# Altium schematic sheet PoE.SchDoc(PoE)
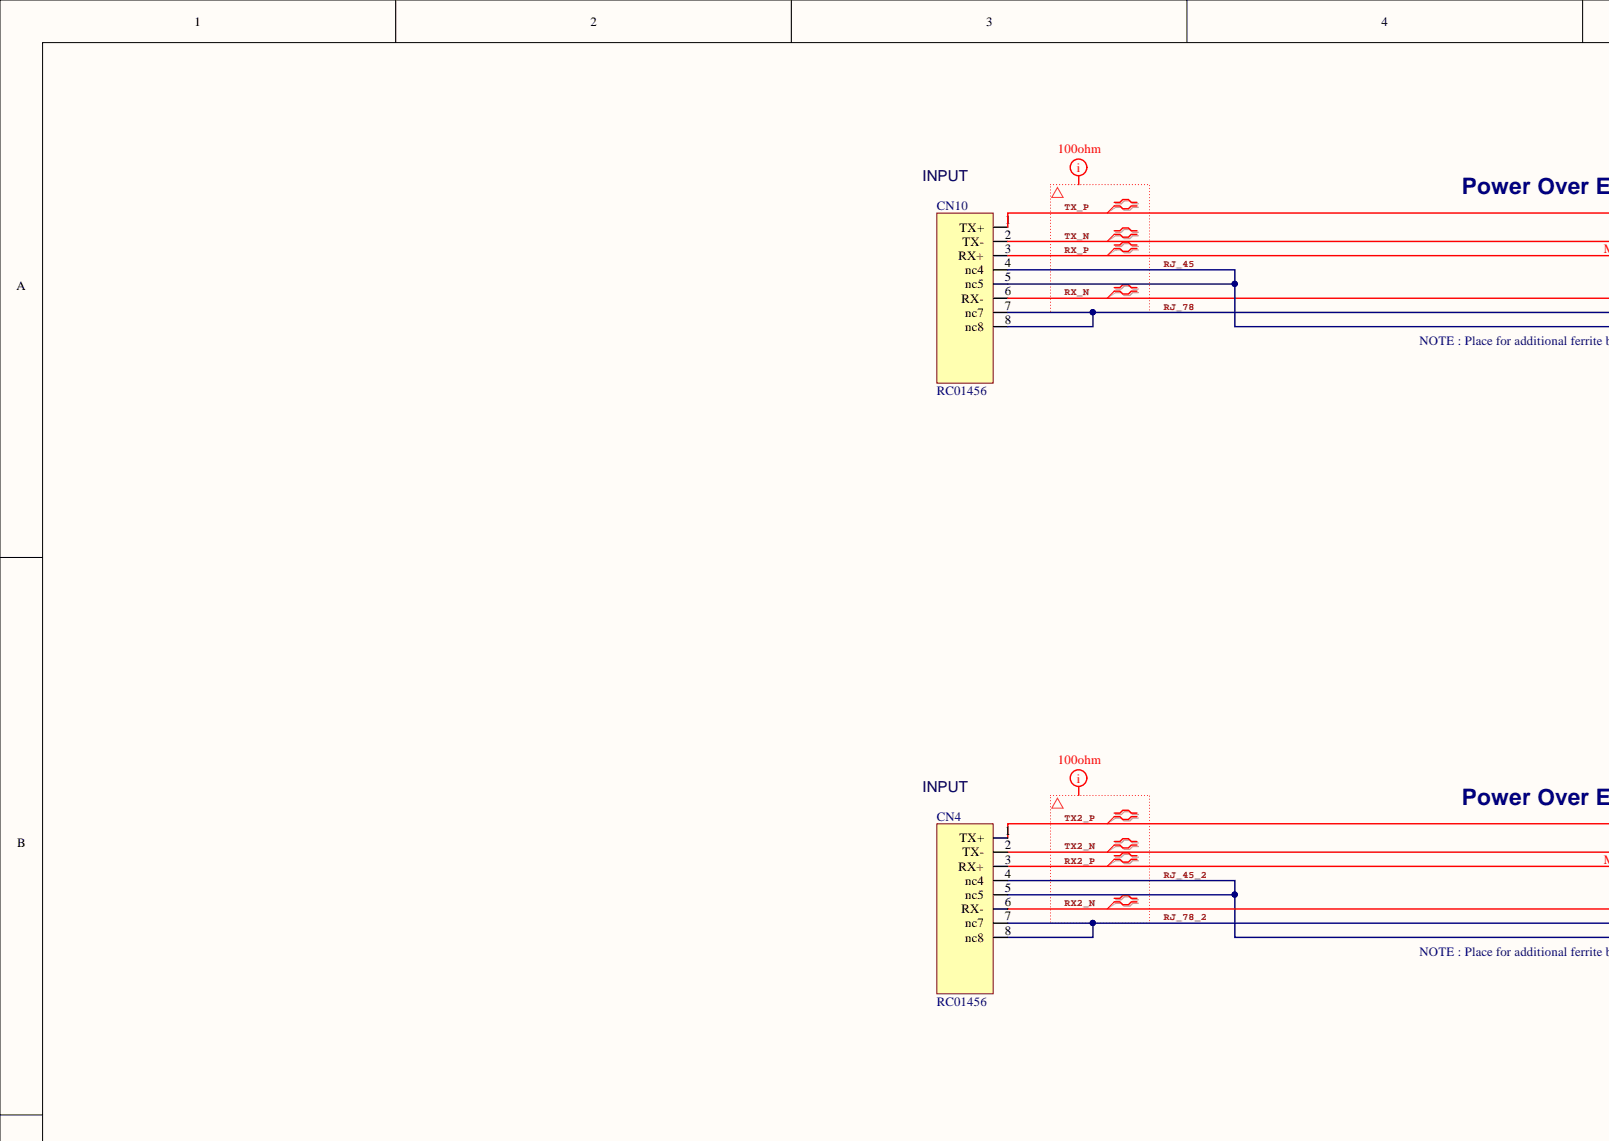
[diagram p0: PoE.SchDoc, top left]
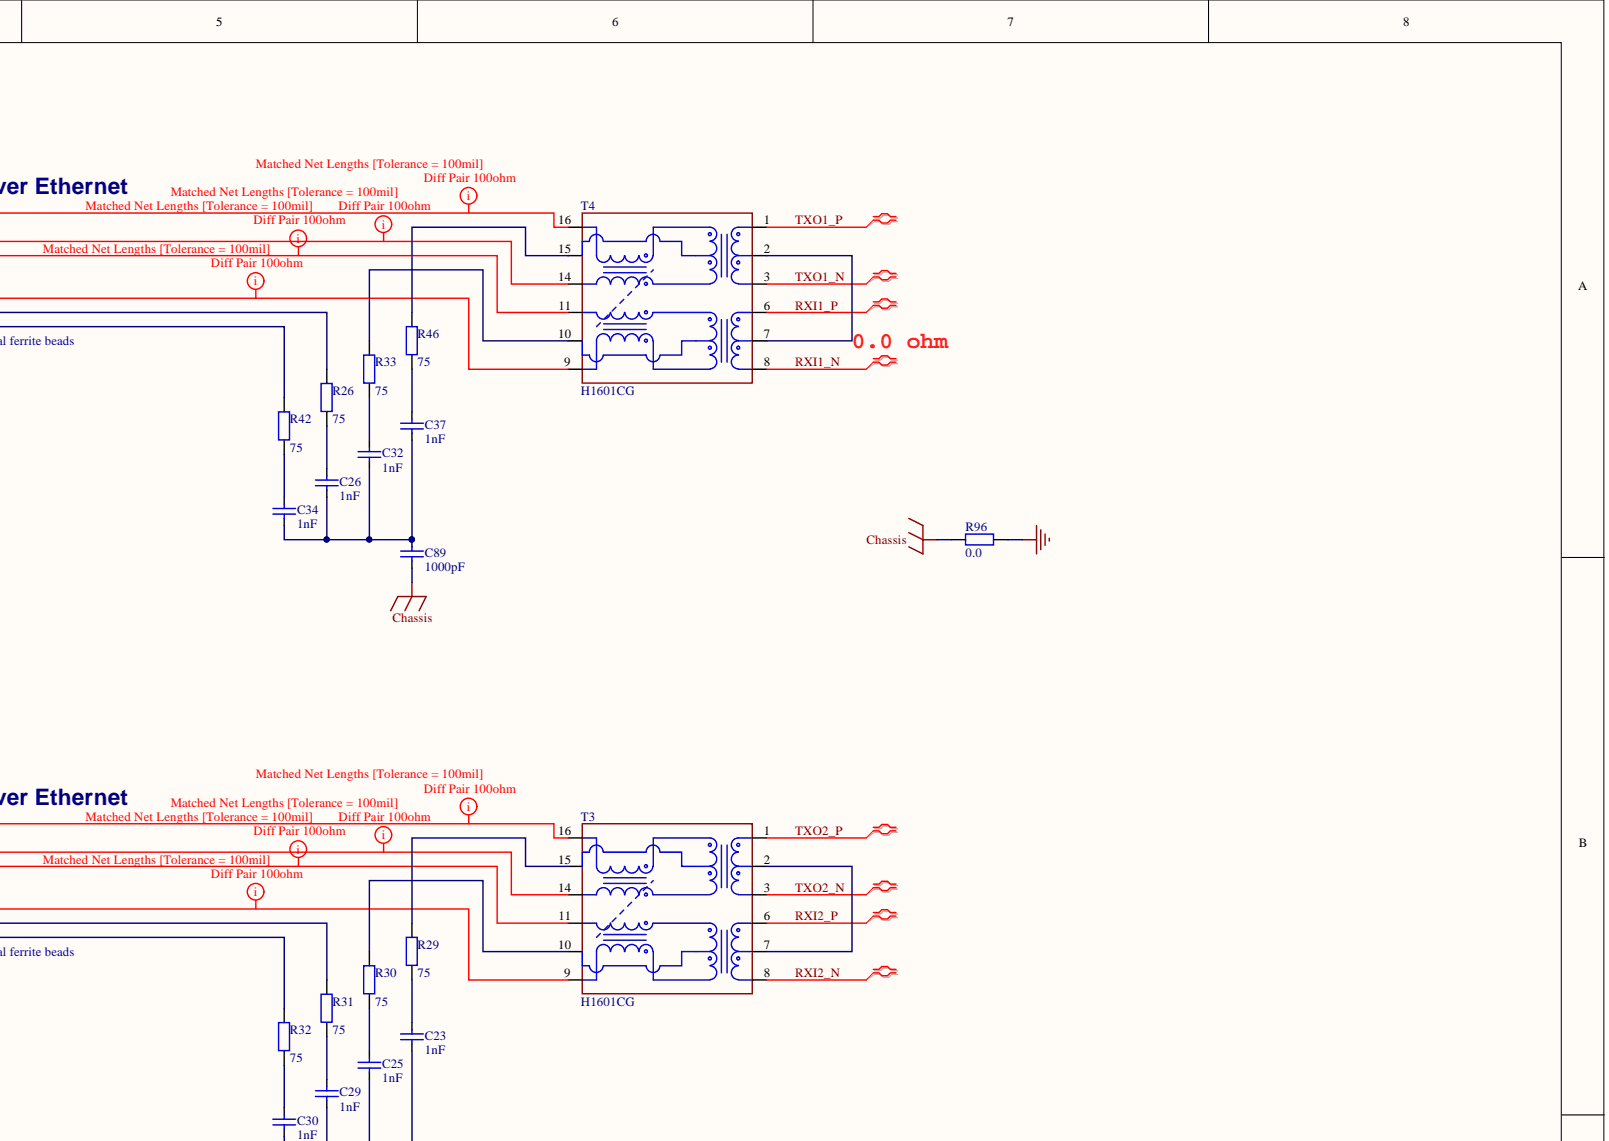
[diagram p1: PoE.SchDoc, top right]
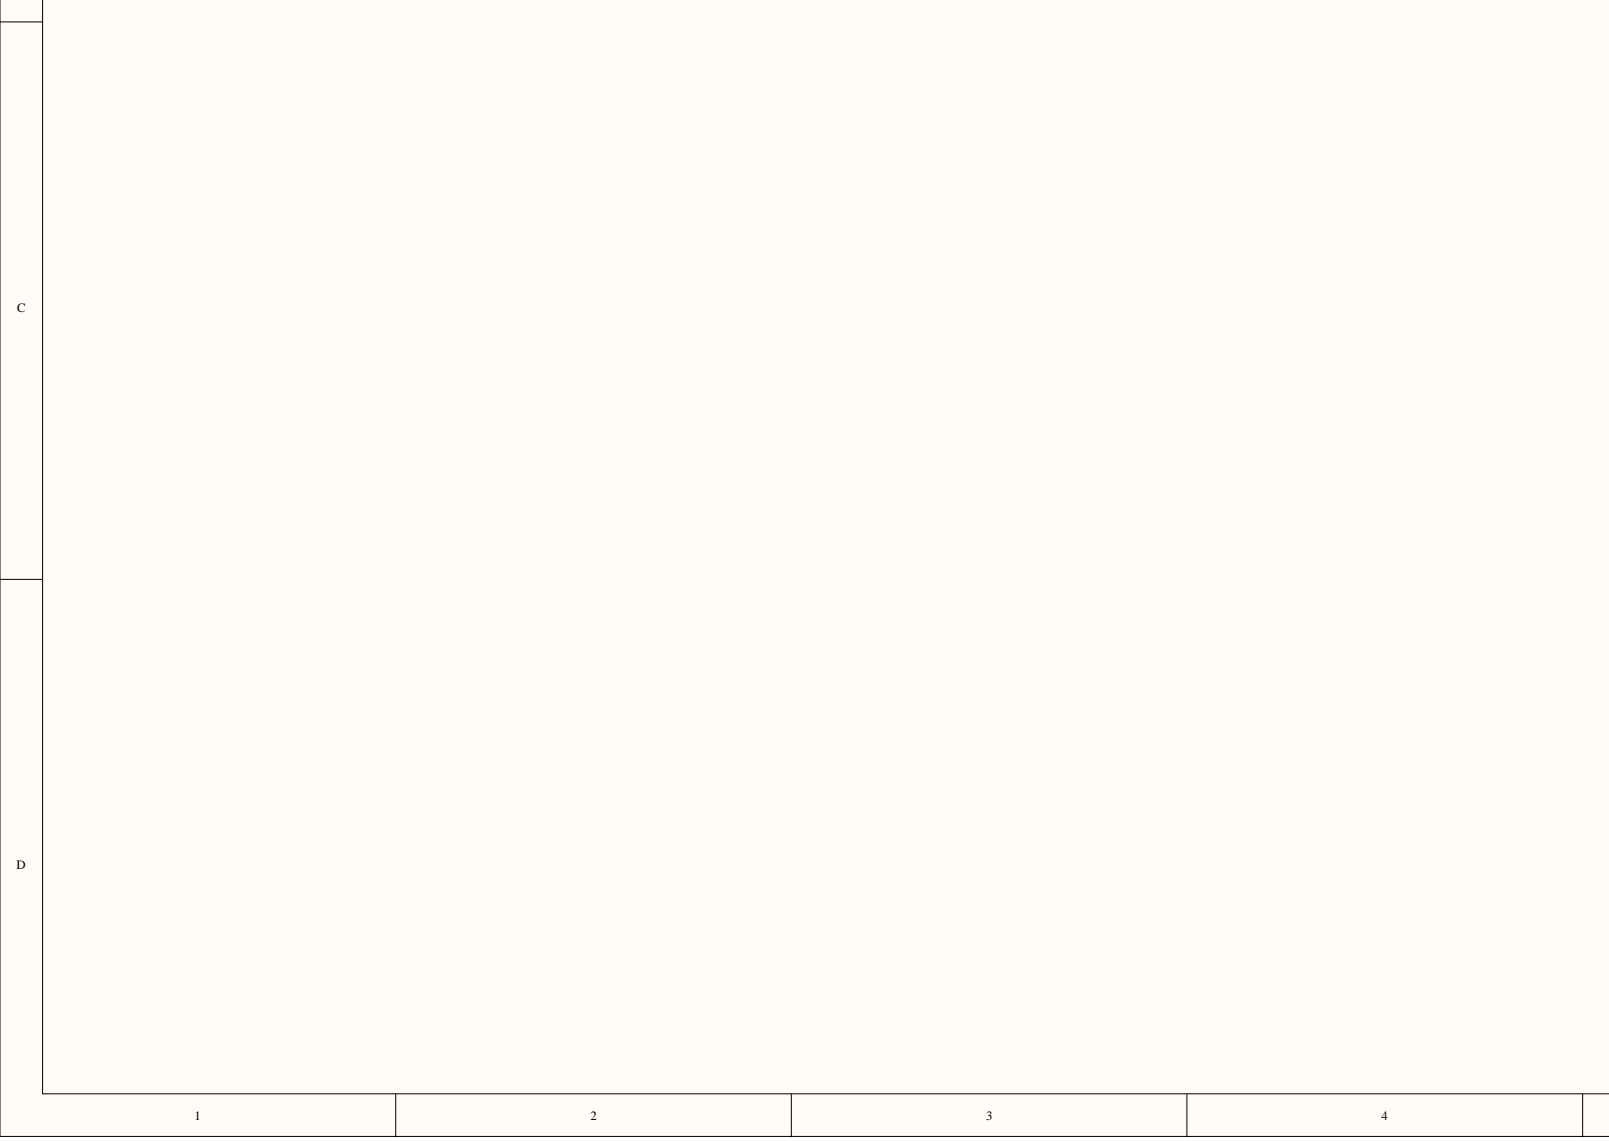
[diagram p2: PoE.SchDoc, bottom left]
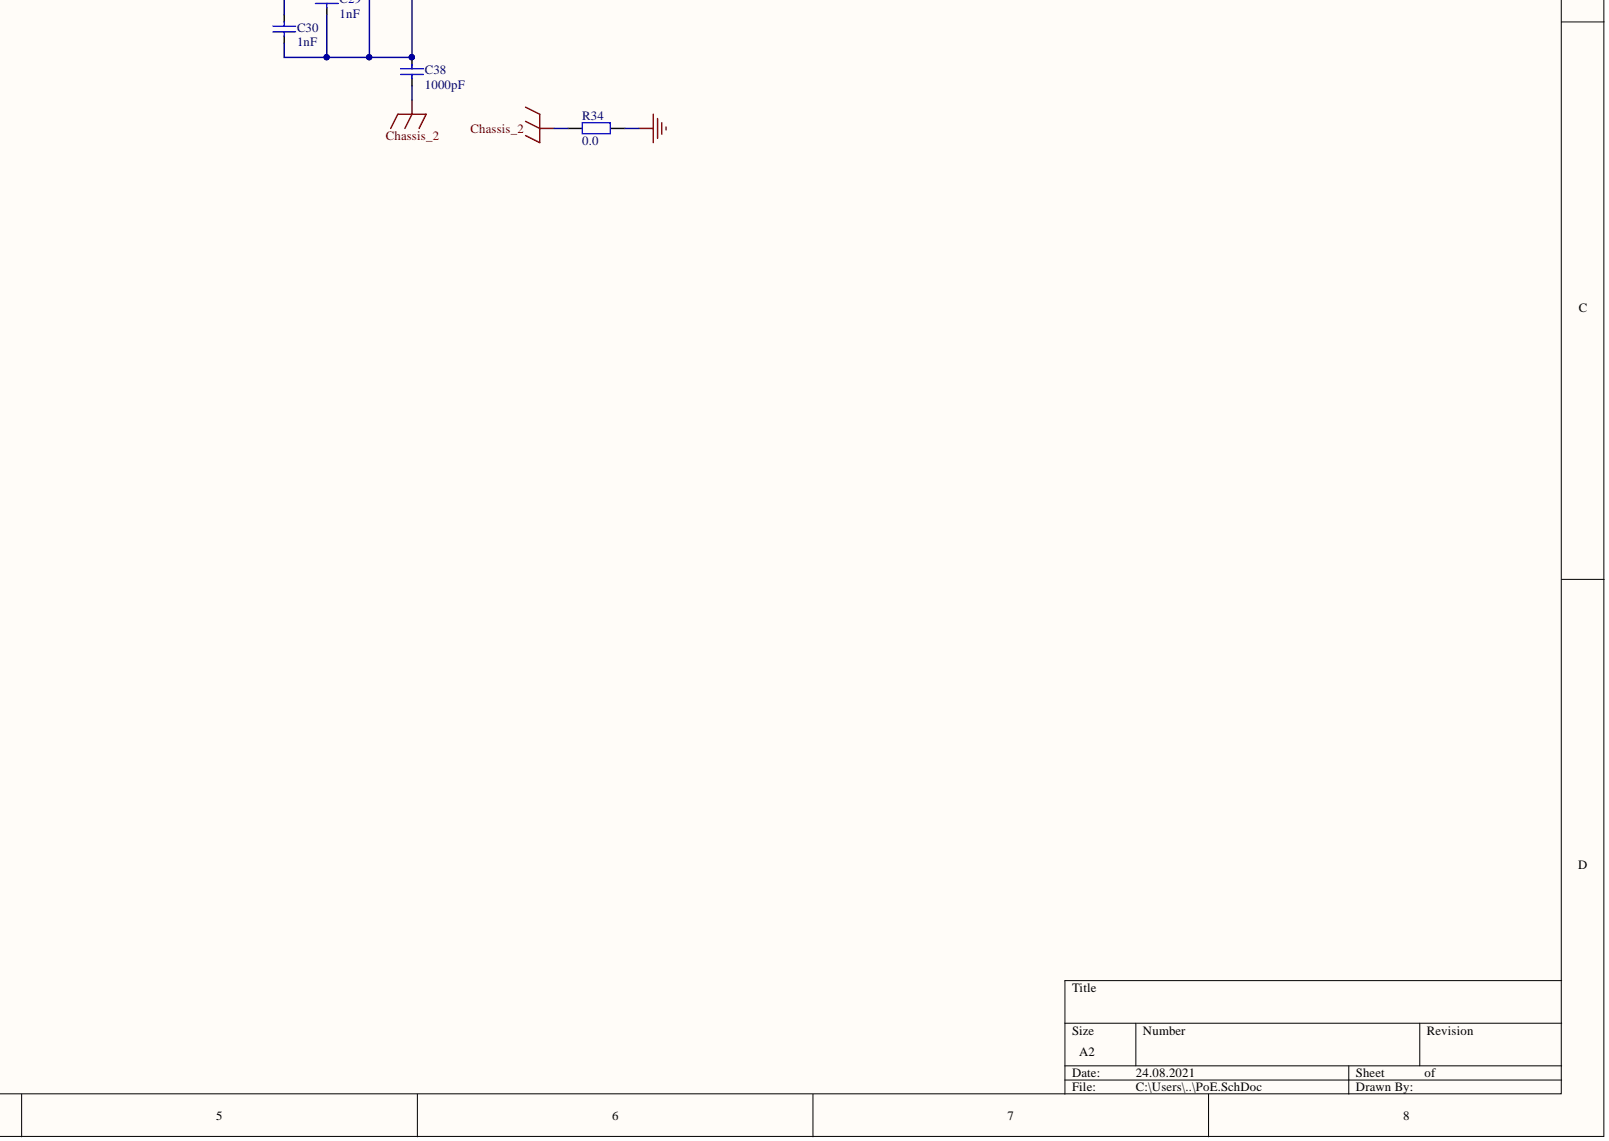
[diagram p3: PoE.SchDoc, bottom right]

## Parts
C23  value 1nF  [CAP0603R]  (hidden comment: CL10B102KC8NNNC)
C25  value 1nF  [CAP0603R]  (hidden comment: CL10B102KC8NNNC)
C26  value 1nF  [CAP0603R]  (hidden comment: CL10B102KC8NNNC)
C29  value 1nF  [CAP0603R]  (hidden comment: CL10B102KC8NNNC)
C30  value 1nF  [CAP0603R]  (hidden comment: CL10B102KC8NNNC)
C32  value 1nF  [CAP0603R]  (hidden comment: CL10B102KC8NNNC)
C34  value 1nF  [CAP0603R]  (hidden comment: CL10B102KC8NNNC)
C37  value 1nF  [CAP0603R]  (hidden comment: CL10B102KC8NNNC)
C38  value 1000pF  [CAP1206R]  (hidden comment: CC1206KKX7RDBB102)
C89  value 1000pF  [CAP1206R]  (hidden comment: CC1206KKX7RDBB102)
CN4  RC01456  [HY506-Footprint-1]
CN10  RC01456  [HY506-Footprint-1]
R26  value 75  [0603]  (hidden comment: 0603WAF750JT5E)
R29  value 75  [0603]  (hidden comment: 0603WAF750JT5E)
R30  value 75  [0603]  (hidden comment: 0603WAF750JT5E)
R31  value 75  [0603]  (hidden comment: 0603WAF750JT5E)
R32  value 75  [0603]  (hidden comment: 0603WAF750JT5E)
R33  value 75  [0603]  (hidden comment: 0603WAF750JT5E)
R34  value 0.0  [0805]  (hidden comment: CMP-022-0137-1)
R42  value 75  [0603]  (hidden comment: 0603WAF750JT5E)
R46  value 75  [0603]  (hidden comment: 0603WAF750JT5E)
R96  value 0.0  [0805]  (hidden comment: CMP-022-0137-1)
T3  H1601CG  [TF-16SM]
T4  H1601CG  [TF-16SM]

## Nets
Chassis: C89.1 R96.1
Chassis_2: C38.1 R34.1
GND: R34.2 R96.2
RJ_45: CN10.4(nc4) CN10.5(nc5) R42.2
RJ_45_2: CN4.4(nc4) CN4.5(nc5) R32.2
RJ_78: CN10.7(nc7) CN10.8(nc8) R26.2
RJ_78_2: CN4.7(nc7) CN4.8(nc8) R31.2
RX2_N: CN4.6(RX-) T3.9
RX2_P: CN4.3(RX+) T3.11
RX_N: CN10.6(RX-) T4.9
RX_P: CN10.3(RX+) T4.11
RXI1_N: T4.8
RXI1_P: T4.6
RXI2_N: T3.8
RXI2_P: T3.6
TX2_N: CN4.2(TX-) T3.14
TX2_P: CN4.1(TX+) T3.16
TX_N: CN10.2(TX-) T4.14
TX_P: CN10.1(TX+) T4.16
TXO1_N: T4.3
TXO1_P: T4.1
TXO2_N: T3.3
TXO2_P: T3.1
NetC23_1: C23.1 C25.1 C29.1 C30.1 C38.2
NetC23_2: C23.2 R29.1
NetC25_2: C25.2 R30.1
NetC26_1: C26.1 C32.1 C34.1 C37.1 C89.2
NetC26_2: C26.2 R26.1
NetC29_2: C29.2 R31.1
NetC30_2: C30.2 R32.1
NetC32_2: C32.2 R33.1
NetC34_2: C34.2 R42.1
NetC37_2: C37.2 R46.1
NetR29_2: R29.2 T3.15
NetR30_2: R30.2 T3.10
NetR33_2: R33.2 T4.10
NetR46_2: R46.2 T4.15
NetT3_2: T3.2 T3.7
NetT4_2: T4.2 T4.7
N00161:
N00162:

## Library data of the parts
C23 C25 C26 C29 C30 C32 C34 C37 = CMP-00190-1: CAP CER 1000PF 100V ±10% X7R 0603; Manufacturer: Samsung; Voltage: 100V; Tolerance: ±10%; Temperature: -55°C ~ 125°C; Technology: X7R; Simulation: CAP; Signal Integrity: Cap; PriceSupplier: https://lcsc.com/product-detail/Multilayer-Ceramic-Capacitors-MLCC-SMD-SMT_SAMSUNG_CL10B102KC8NNNC_1nF-102-10-100V_C153291.html; PriceQty: 50; Price: 0,0043; Pin Count: 2; Part Number: CL10B102KC8NNNC; Library Name: <Company> Vault; HelpURL: https://lcsc.com/product-detail/Multilayer-Ceramic-Capacitors-MLCC-SMD-SMT_SAMSUNG_CL10B102KC8NNNC_1nF-102-10-100V_C153291.html; Designator: CL10B102KC8NNNC; Component Name: CL10B102KC8NNNC; Component Kind: Standard
C38 C89 = CMP-004-0061-2: CAP CER 1000PF 2KV ±10% X7R 1206; Manufacturer: Yageo; Voltage: 2kV; Tolerance: ±10%; Temperature: -55°C ~ 125°C; Technology: X7R; Simulation: CAP; Signal Integrity: Cap; PriceSupplier: https://lcsc.com/product-detail/Multilayer-Ceramic-Capacitors-MLCC-SMD-SMT_YAGEO-CC1206KKX7RDBB102_C23631.html; PriceQty: 50; Price: 0,0114; Pin Count: 2; Part Number: CC1206KKX7RDBB102; Library Name: <Company> Vault; HelpURL: https://www.digikey.com/product-detail/en/yageo/CC1206KKX7RDBB102/311-1487-1-ND/2833793; Component Name: CC1206KKX7RDBB102; Component Kind: Standard
CN4 CN10 = CMP-00197-2: 8 Pin RJ45 Socket; Manufacturer: RCH; Temperature: -40°c~85°c; PriceSupplier: https://lcsc.com/product-detail/Ethernet-Connectors-Modular-Connectors-RJ45-RJ11_RCH-RC01456_C708633.html; PriceQty: 120; Price: 0,0476; Pin Count: 8; Part Number: RC01456; Library Name: <Company> Vault; HelpURL: https://lcsc.com/product-detail/Ethernet-Connectors-Modular-Connectors-RJ45-RJ11_RCH-RC01456_C708633.html; Designator: RC01456; Component Name: RC01456; Component Kind: Standard
R26 R29-R33 R42 R46 = CMP-00189-1: RES SMD 75 OHM 1% 1/10W 0603; Manufacturer: Uniroyal; Tolerance: ±1%; Temperature: -55°C ~ 155°C; Simulation: RESISTOR; Signal Integrity: Res; PriceSupplier: https://lcsc.com/product-detail/Chip-Resistor-Surface-Mount_Uniroyal-Elec-0603WAF750JT5E_C4275.html; PriceQty: 1; Price: 0,0023; Pin Count: 2; Part Number: 0603WAF750JT5E; Library Name: <Company> Vault; HelpURL: https://lcsc.com/product-detail/Chip-Resistor-Surface-Mount_Uniroyal-Elec-0603WAF750JT5E_C4275.html; Designator: 0603WAF750JT5E; Component Name: 0603WAF750JT5E; Component Kind: Standard
R34 R96 = CMP-022-0137-1: RES SMD 0 OHM JUMPER 1/8W 0805; Part Number: RC0805JR-070RL; Manufacturer: Yageo; Temperature: -55°C ~ 155°C; Simulation: RESISTOR; Signal Integrity: Res; PriceSupplier: https://lcsc.com/product-detail/Chip-Resistor-Surface-Mount_YAGEO-RC0805JR-070RL_C96345.html; PriceQty: 100; Price: 0,001203; Pin Count: 2; Library Name: <Company> Vault; HelpURL: https://www.digikey.com/product-detail/en/yageo/RC0805JR-070RL/311-0.0ARCT-ND/731163; Component Name: RC0805JR-070RL; Component Kind: Standard
T3 T4 = CMP-00191-2: 1:1 350 -1.1 -18 SMD-16 RJ45 Transformer; Manufacturer: Dongguan; Temperature: H1601CG; PriceSupplier: https://lcsc.com/product-detail/RJ45-Transformer_Dongguan-Mentech-Optical-Magnetic-H1601CG_C194517.html; PriceQty: 1; Price: 0,263; Pin Count: 10; Part Number: H1601CG; Library Name: <Company> Vault; HelpURL: https://lcsc.com/product-detail/RJ45-Transformer_Dongguan-Mentech-Optical-Magnetic-H1601CG_C194517.html; Designator: H1601CG; Component Name: H1601CG; Component Kind: Standard
